# Altium schematic sheet H-00491 - Top.SchDoc
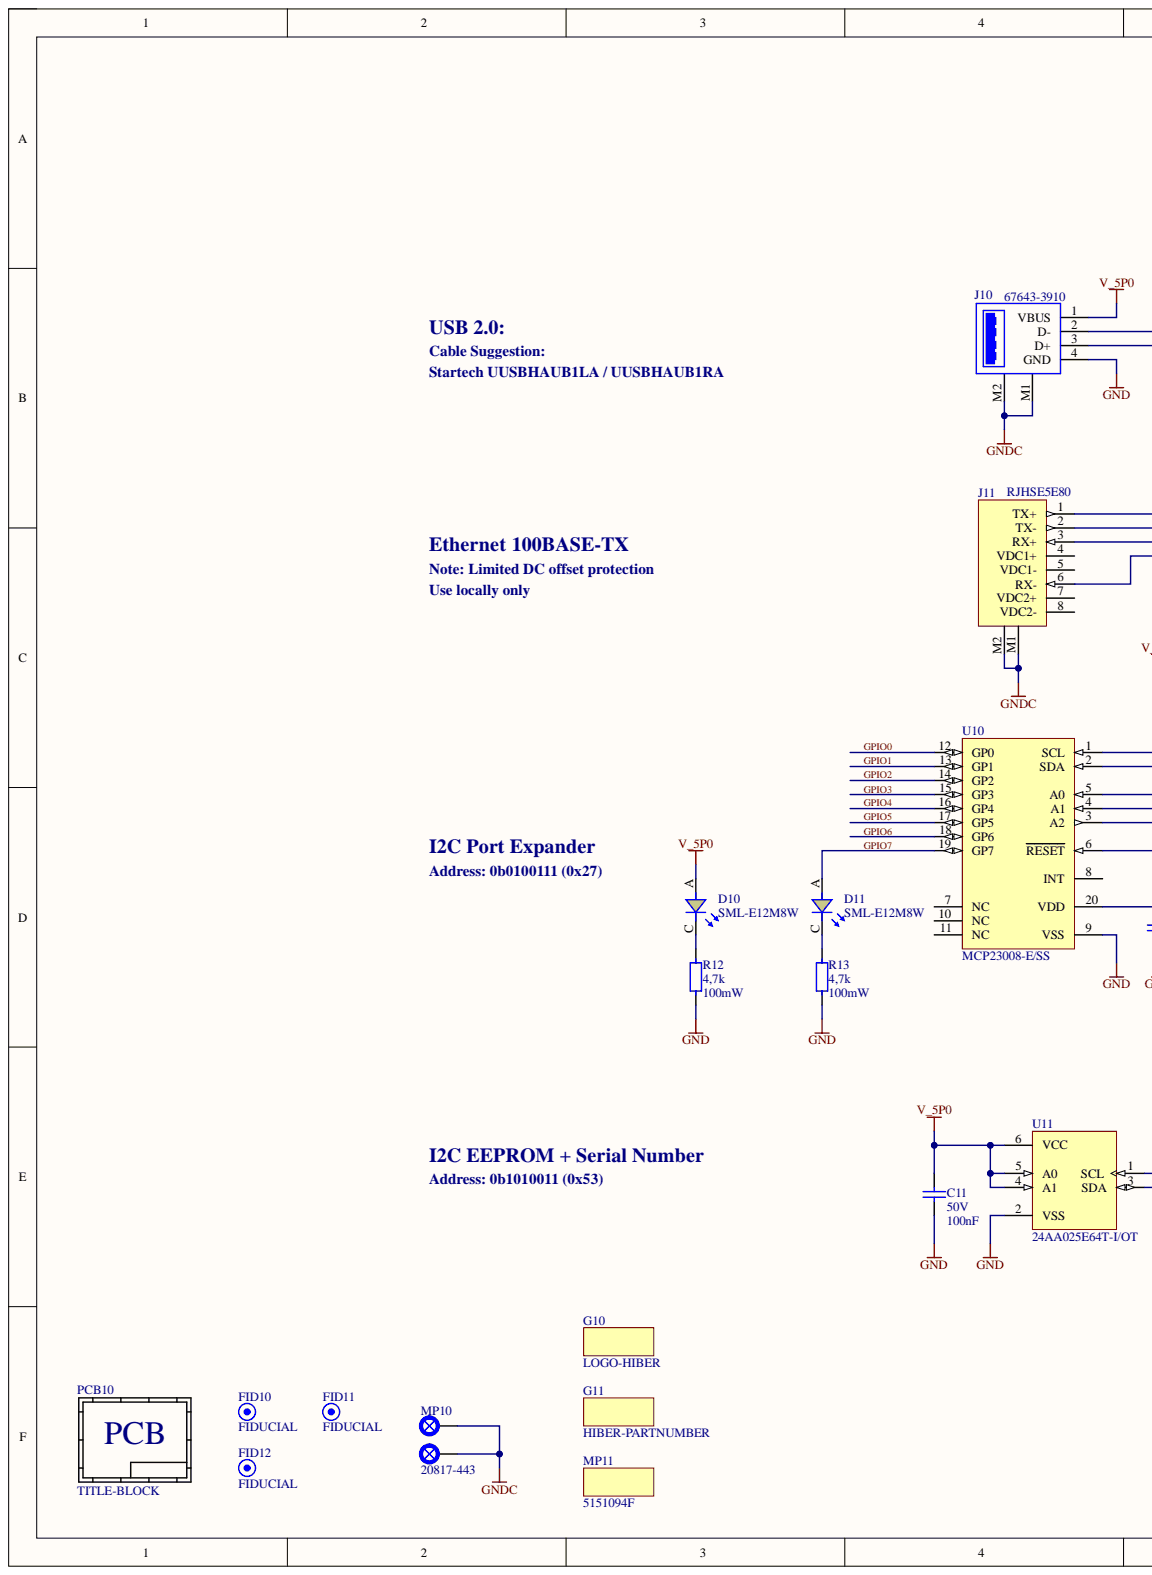
[diagram p0: H-00491 - Top.SchDoc, left]
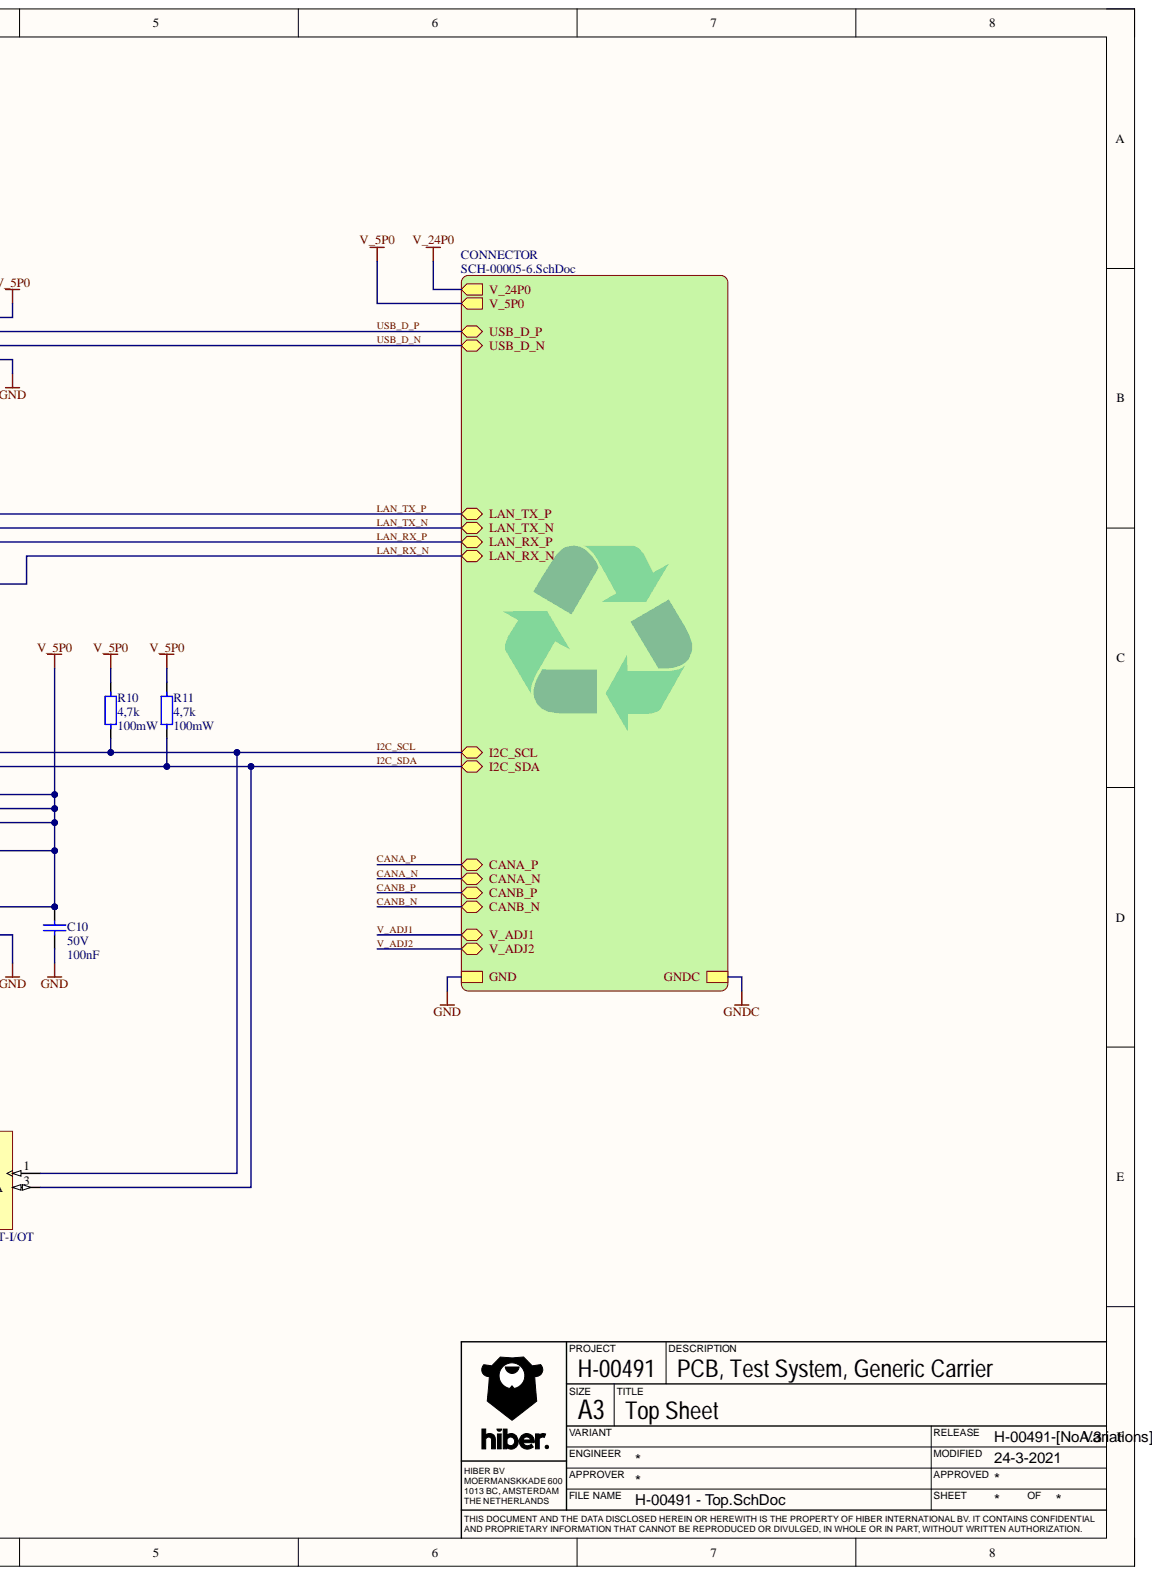
[diagram p1: H-00491 - Top.SchDoc, right]

## Parts
C10  value 100nF  Rated Voltage: 50V  [CAPC160X80X87L35N]  (hidden comment: C0603C104K5RACTU)
C11  value 100nF  Rated Voltage: 50V  [CAPC160X80X87L35N]  (hidden comment: C0603C104K5RACTU)
D10  SML-E12M8W  [LEDC170X80X80L20_YELLOW]
D11  SML-E12M8W  [LEDC170X80X80L20_YELLOW]
FID10  FIDUCIAL  [FIDUCIAL_075X150]  (no BOM)
FID11  FIDUCIAL  [FIDUCIAL_075X150]  (no BOM)
FID12  FIDUCIAL  [FIDUCIAL_075X150]  (no BOM)
G10  LOGO-HIBER  [HIBER_LOGO_COPPER_55x40]  (no BOM)
G11  HIBER-PARTNUMBER  [HIBER_PNSN_COPPER]  (no BOM)
J10  67643-3910  [MOLEX_676433910]
J11  RJHSE5E80  [AMPHENOLICC_RJHSE5E80]
MP10  20817-443  [SCHROFF_20817443]
MP11  5151094F  [DIALIGHT_5151094F]
PCB10  TITLE-BLOCK  [TITLEBLOCK-A4]
R10  value 4,7k  Rated Power: 100mW  [RESC160X85X55L30N]  (hidden comment: CRCW06034K70FKEA)
R11  value 4,7k  Rated Power: 100mW  [RESC160X85X55L30N]  (hidden comment: CRCW06034K70FKEA)
R12  value 4,7k  Rated Power: 100mW  [RESC160X85X55L30N]  (hidden comment: CRCW06034K70FKEA)
R13  value 4,7k  Rated Power: 100mW  [RESC160X85X55L30N]  (hidden comment: CRCW06034K70FKEA)
U10  MCP23008-E/SS  [SOP20P65_720X780X200L75X30N]
U11  24AA025E64T-I/OT  [SOT23-6P95_270X145L35X35]

## Nets
GND: C10.2 C11.2 J10.4(GND) R12.2 R13.2 U10.9(VSS) U11.2(VSS)
GNDC: J10.M1 J10.M2 J11.M1 J11.M2 MP10.M1 MP10.M2
V_5P0: C10.1 C11.1 D10.A J10.1(VBUS) R10.1 R11.1 U10.3(A2) U10.4(A1) U10.5(A0) U10.6(RESET) U10.20(VDD) U11.4(A1) U11.5(A0) U11.6(VCC)
GPIO0: U10.12(GP0)
GPIO1: U10.13(GP1)
GPIO2: U10.14(GP2)
GPIO3: U10.15(GP3)
GPIO4: U10.16(GP4)
GPIO5: U10.17(GP5)
GPIO6: U10.18(GP6)
GPIO7: D11.A U10.19(GP7)
I2C_SCL: R10.2 U10.1(SCL) U11.1(SCL)
I2C_SDA: R11.2 U10.2(SDA) U11.3(SDA)
LAN_RX_N: J11.6(RX-)
LAN_RX_P: J11.3(RX+)
LAN_TX_N: J11.2(TX-)
LAN_TX_P: J11.1(TX+)
USB_D_N: J10.3(D+)
USB_D_P: J10.2(D-)
NetD10_C: D10.C R12.1
NetD11_C: D11.C R13.1
NetJ11_4: J11.4(VDC1+)
NetJ11_5: J11.5(VDC1-)
NetJ11_7: J11.7(VDC2+)
NetJ11_8: J11.8(VDC2-)
NetU10_7: U10.7(NC)
NetU10_8: U10.8(INT)
NetU10_10: U10.10(NC)
NetU10_11: U10.11(NC)
CANA_N:
CANA_P:
CANB_N:
CANB_P:
V_24P0:
V_ADJ1:
V_ADJ2:

## Library data of the parts
C10 C11 = CMP-00418-1: CAP Standard 0603 (1608) 100,0nF 50V X7R (Standard); Tolerance: 10%; Type: Ceramic; Case/Package: 0603 (1608); Component Kind: Standard; Dielectric: X7R; Failure Rate: N/A; Mount: Surface Mount; Pins: 2; Qualification: Standard; RoHS: Yes; Termination Finish: 100% Matte Sn; Library Name: Hiber
D10 D11 = CMP-00429-1: LED Yellowish green Diffused 0603 SMD; Chip Structure: AlGaInP; Dominant Wavelength: 630nm; Forward Current: 20mA; Forward Voltage: 2,4V; Illumination Colour: Red; Lens Colour: White; Lens Style: Clear, Colorless, Diffused, Rectangular; Luminous Intensity: 25mcd; Number of LEDs: 1; Typical Wavelength: 572nm; Viewing Angle: 140°; Case Code (Imperial): 0603; Case Code (Metric): 1608; Case/Package: 0603; Color: Yellowish green; Component Kind: Standard; Height: 0.46mm; Lead Free: Lead Free; Length: 1.6mm; Lens Transparency: Diffused; Max Operating Temperature: 85°C; Min Operating Temperature: -40°C; Mount: Surface Mount; Number of Pins: 2; Package Quantity: 1; Packaging: Tape and Reel; Radiation Hardening: No; Test Current: 20mA; Wavelength: 630nm; Width: 0.8mm; Library Name: Hiber
FID10-FID12 = CMP-00519-1: Description: Fiducial; Library Name: Hiber Vault
G10 = CMP-00553-6: Hiber Logo; Library Name: Hiber
G11 = CMP-00558-6: Hiber Fabrication Partnumber; Library Name: Hiber
J10 = CMP-01203-2: USB Connector, 4 Contact(s), Female, Right Angle, Solder Terminal, Locking, Receptacle; Contact Resistance: 30m; Component Kind: Standard; Mount: Board; RoHS: Yes; Library Name: Hiber
J11 = CMP-00838-3: Modular Connectors / Ethernet Connectors High Speed RJ w/no LEDs or Shield; Connector Type: Jack; Current Rating: 1.5A; Direction: Up; Gender: Female; Insulation Resistance: 500MR; Number of Contacts: 8; Number of Ports: 1; Pitch: 2.03mm; Shielding: Shielded; Voltage Rating: 125V; Color: Black; Component Kind: Standard; Contact Material: Bronze; Contact Plating: Gold; Flammability Rating: UL94 V-0; Housing Material: Thermoplastic; Max Operating Temperature: 85°C; Min Operating Temperature: -55°C; Mount: Through Hole; Number of Positions: 8; Number of Rows: 1; Orientation: Right Angle; Package Quantity: 120; Packaging: Tray; RoHS: Yes; Termination: Solder; Library Name: Hiber
MP10 = CMP-01152-2: SCHROFF         20817-443             MODULE, PLUG-IN, 3U, 4HP; Component Kind: Standard; Library Name: Hiber
MP11 = CMP-01206-1: Optical Pipe; Component Kind: Standard; Optoelectronic Device Type: OPTICAL PIPE; REACH SVHC: Yes; RoHS Compliant: Yes; Library Name: Hiber
PCB10 = CMP-00011-3: Title Block and PCB BOM Line Item; Component Kind: Standard; Library Name: Hiber
R10-R13 = CMP-00113-4: RES Standard Thick Film 0603 4K70 ±1% 0,1W; Qualification: Automotive; Rated Voltage: 75V; Case/Package: 0603 (1608); Component Kind: Standard; Failure Rate: N/A; Mount: Surface Mount; Pins: 2; RoHS: Yes; Termination Finish: Pure tin solder contacts on Ni barrier layer; Tolerance: 1%; Type: Standard Thick Film; Library Name: Hiber
U10 = CMP-01204-1: Parallel I/O Port, 8-Bit, 8 I/O, CMOS, PDSO20; Component Kind: Standard; Library Name: Hiber
U11 = CMP-01106-2: EEPROM, 256X8, Serial, CMOS, PDSO5; Serial Bus Type: I2C; Time @ Peak Reflow Temperature-Max (s): 40s; Component Kind: Standard; JESD-609 Code: e3; Memory Type: EEPROM; Moisture Sensitivity Level: 1; Peak Reflow Temperature (Cel): 260°C; Terminal Finish: Tin; Library Name: Hiber
